# Altium schematic sheet Sheet6.SchDoc(Sheet6)
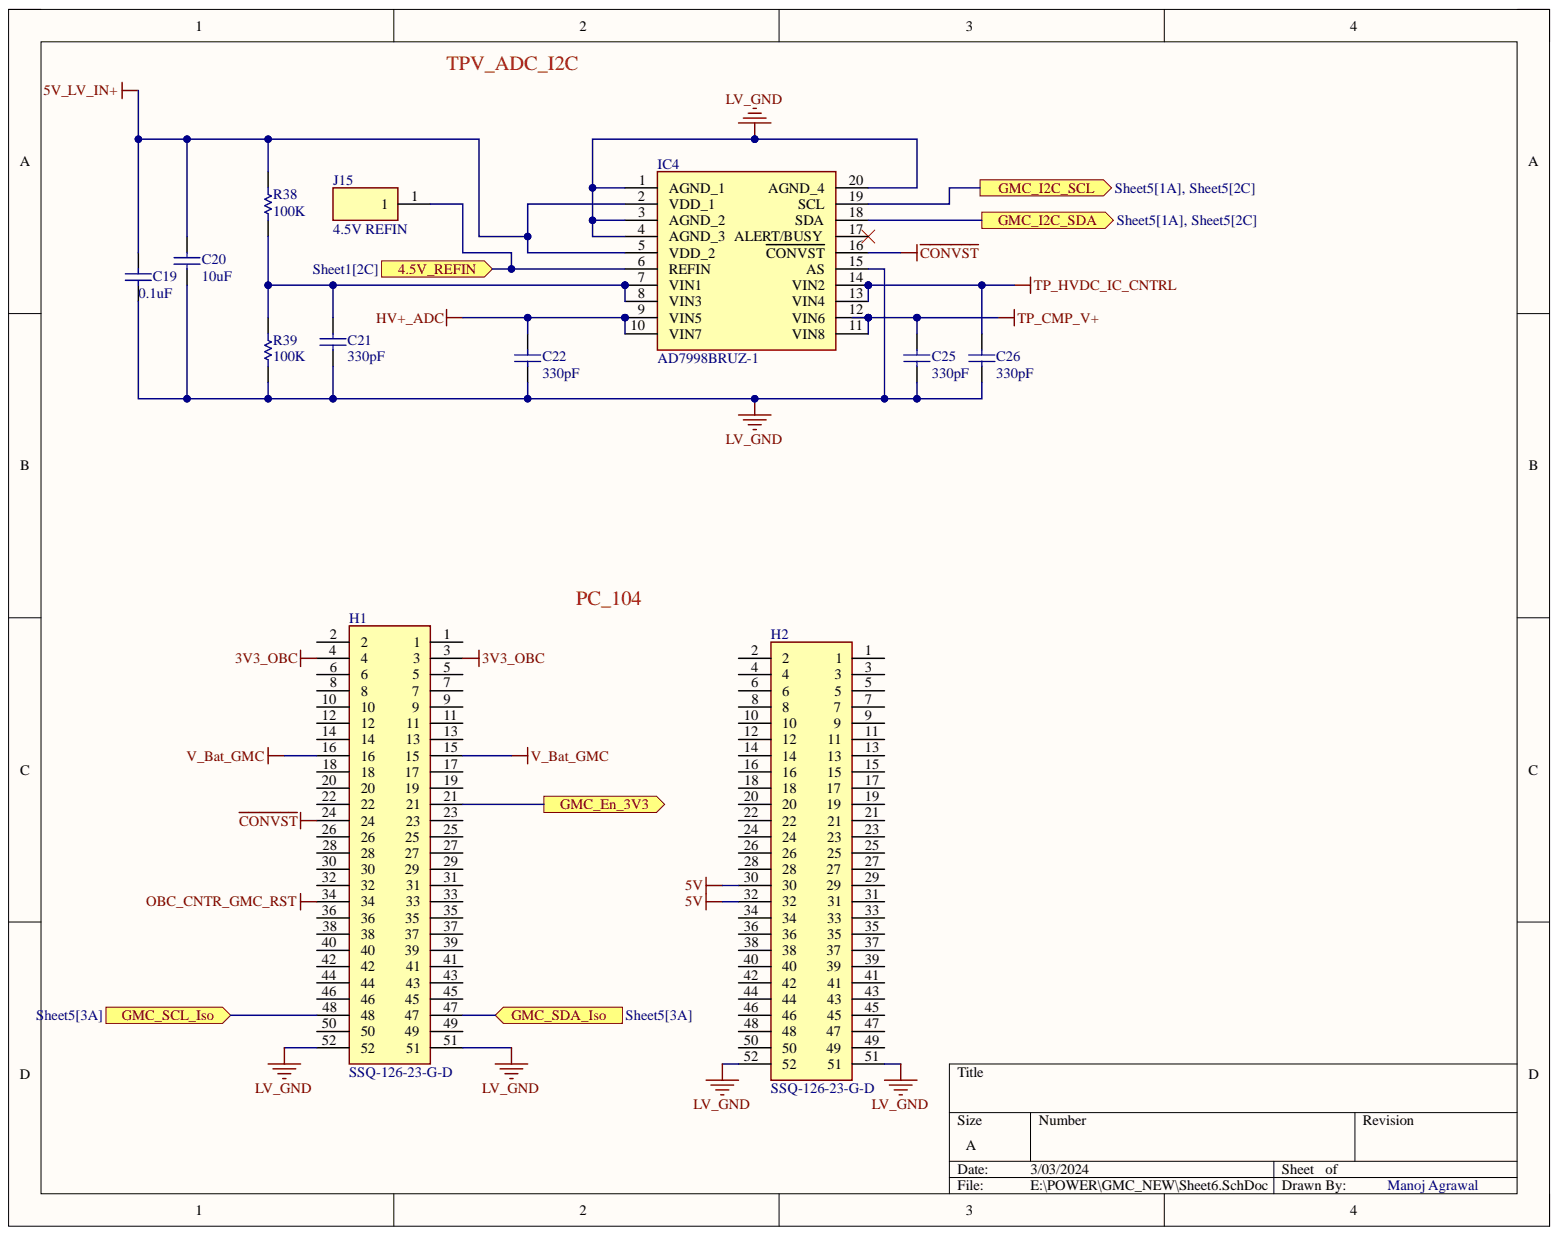
[diagram p0: Sheet6.SchDoc]

## Parts
C19  0.1uF  [CAPC2013X88X50LL20T25]
C20  10uF  [CAPC2013X88X50LL20T25]
C21  330pF  [CAPC2013X88X50LL20T25]
C22  330pF  [CAPC2013X88X50LL20T25]
C25  330pF  [CAPC2013X88X50LL20T25]
C26  330pF  [CAPC2013X88X50LL20T25]
H1  SSQ-126-23-G-D  [SAMTEC_SSQ-126-23-G]
H2  SSQ-126-23-G-D  [SAMTEC_SSQ-126-23-G]
IC4  AD7998BRUZ-1  [SOP65P640X120-20N]
J15  4.5V REFIN  [HDRV1W64P0X254_1X1_254X248X838P]
R38  100K  [RESC2013X50X30LL20T20]
R39  100K  [RESC2013X50X30LL20T20]

## Ports
4.5V_REFIN, GMC_En_3V3, GMC_I2C_SCL, GMC_I2C_SDA, GMC_SCL_Iso, GMC_SDA_Iso

## Nets
3V3_OBC: H1.3 H1.4
5V: H2.30 H2.32
5V_LV_IN+: C19.2 C20.2 IC4.2(VDD_1) IC4.5(VDD_2) R38.2
~{CONVST}: H1.24 IC4.16(CONVST)
HV+_ADC: C22.2 IC4.9(VIN5) IC4.10(VIN7)
LV_GND: C19.1 C20.1 C21.1 C22.1 C25.1 C26.1 H1.51 H1.52 H2.51 H2.52 IC4.1(AGND_1) IC4.3(AGND_2) IC4.4(AGND_3) IC4.15(AS) IC4.20(AGND_4) R39.1
OBC_CNTR_GMC_RST: H1.34
TP_CMP_V+: C25.2 IC4.11(VIN8) IC4.12(VIN6)
TP_HVDC_IC_CNTRL: C26.2 IC4.13(VIN4) IC4.14(VIN2)
V_Bat_GMC: H1.15 H1.16
4.5V_REFIN: IC4.6(REFIN) J15.1 ; port 4.5V_REFIN
GMC_En_3V3: H1.21 ; port GMC_En_3V3
GMC_I2C_SCL: IC4.19(SCL) ; port GMC_I2C_SCL
GMC_I2C_SDA: IC4.18(SDA) ; port GMC_I2C_SDA
GMC_SCL_Iso: H1.48 ; port GMC_SCL_Iso
GMC_SDA_Iso: H1.47 ; port GMC_SDA_Iso
NetC21_2: C21.2 IC4.7(VIN1) IC4.8(VIN3) R38.1 R39.2

Not connected: H1 1 2 5 6 7 8 9 10 11 12 13 14 17 18 19 20 22 23 25 26 27 28 29 30 31 32 33 35 36 37 38 39 40 41 42 43 44 45 46 49 50; H2 1 2 3 4 5 6 7 8 9 10 11 12 13 14 15 16 17 18 19 20 21 22 23 24 25 26 27 28 29 31 33 34 35 36 37 38 39 40 41 42 43 44 45 46 47 48 49 50; IC4 17(ALERT/BUSY)

## Library data of the parts
C19-C22 C25 C26 = CMP-2007-00266-1: Manufacturer: Kemet; Part Number: C0805C103K5RACTU; Component Kind: Standard; Library Name: Altium Content Vault
H1 H2 = SSQ-126-23-G-D: Connector; Manufacturer_Name: SAMTEC; Arrow Part Number: SSQ-126-23-G-D; Arrow Price/Stock: https://www.arrow.com/en/products/ssq-126-23-g-d/samtec; Component Kind: Standard; Datasheet Link: http://suddendocs.samtec.com/prints/ssq-1xx-xx-xxx-x-xx-xxx-xx-x-mkt.pdf; Library Name: OBC3.0.SchLib; Manufacturer_Part_Number: SSQ-126-23-G-D; Mouser Part Number: 200-SSQ12623GD; Mouser Price/Stock: https://www.mouser.com/Search/Refine.aspx?Keyword=200-SSQ12623GD
IC4 = AD7998BRUZ-1: Integrated Circuit; Manufacturer_Name: Analog Devices; Arrow Part Number: AD7998BRUZ-1; Arrow Price/Stock: https://www.arrow.com/en/products/ad7998bruz-1/analog-devices?region=nac; Component Kind: Standard; Datasheet Link: https://www.analog.com/media/en/technical-documentation/data-sheets/AD7997_7998.pdf; Height: 1.2mm; Manufacturer_Part_Number: AD7998BRUZ-1; Mouser Part Number: 584-AD7998BRUZ-1; Mouser Price/Stock: https://www.mouser.co.uk/ProductDetail/Analog-Devices/AD7998BRUZ-1?qs=%2FtpEQrCGXCzLShtTsOA9Rw%3D%3D
J15 = HTSW-101-07-L-S: Connector; Manufacturer_Name: SAMTEC; Arrow Part Number: HTSW-101-07-L-S; Arrow Price/Stock: https://www.arrow.com/en/products/htsw-101-07-l-s/samtec; Component Kind: Standard; Datasheet Link: https://www.arrow.com/en/products/htsw-101-07-l-s/samtec; Height: 8.38mm; Library Name: OBC3.0.SchLib; Manufacturer_Part_Number: HTSW-101-07-L-S; Mouser Part Number: 200-HTSW10107LS; Mouser Price/Stock: https://www.mouser.co.uk/ProductDetail/Samtec/HTSW-101-07-L-S?qs=0lQeLiL1qybicCfG80ZrYQ%3D%3D
R38 R39 = CMP-2001-04896-1: Manufacturer: Vishay Dale; Part Number: CRCW08050000Z0EA; Component Kind: Standard; Library Name: Altium Content Vault
